# Altium schematic sheet Shunt.SchDoc
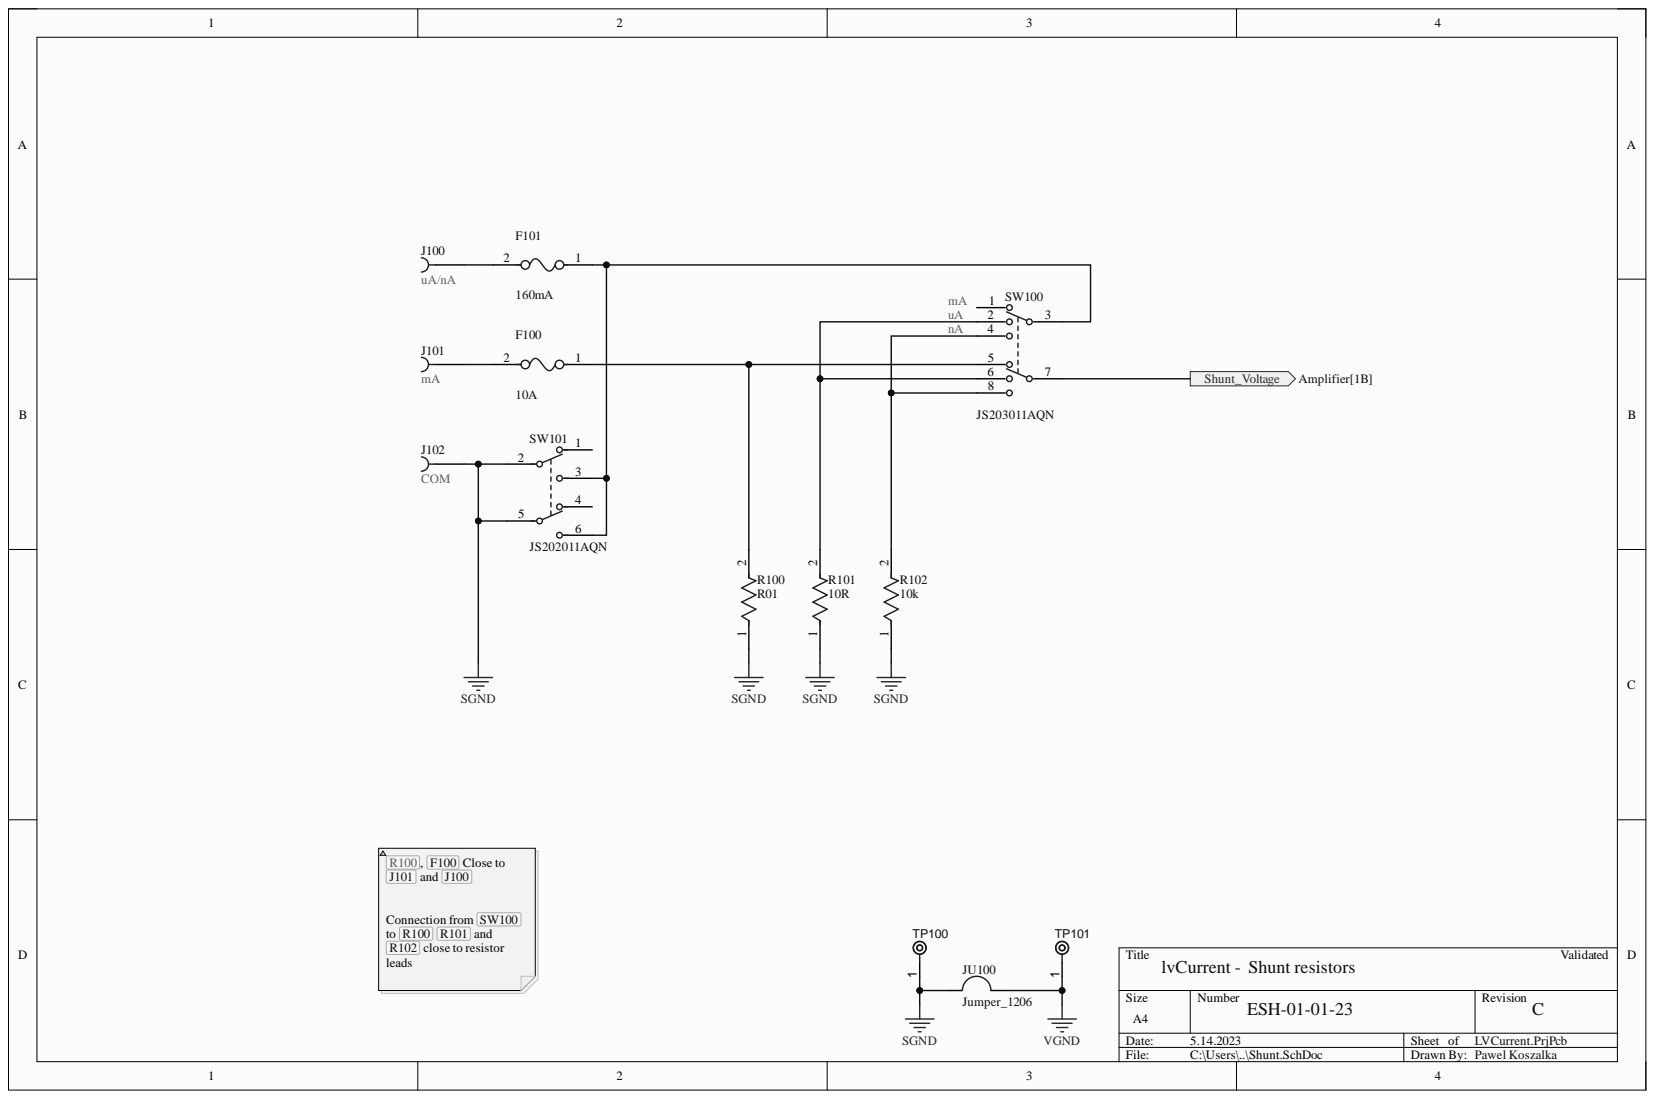
[diagram p0: Shunt.SchDoc]

## Parts
F100  10A  [FP-3413_0330_22-MFG]
F101  160mA  [FP-3413_0330_22-MFG]
J100  D-3230  [BananaConnector_4mm_long]  (value not drawn)
J101  D-3230  [BananaConnector_4mm_long]  (value not drawn)
J102  D-3231  [BananaConnector_4mm_long]  (value not drawn)
JU100  Jumper_1206  [Jumper_1206]
R100  R01  [FP-CRCW2512-e3-MFG]
R101  10R  [FP-RC1206-MFG]
R102  10k  [FP-RC1206-MFG]
SW100  JS203011AQN  [FP-JS203011AQN-MFG]
SW101  JS202011AQN  [FP-JS202011AQN-MFG]
TP100  TPAD6R  [TP06R]  (value not drawn)  (no BOM)
TP101  TPAD6R  [TP06R]  (value not drawn)  (no BOM)

## Ports
Shunt_Voltage

## Nets
SGND: J102.1 JU100.2 R100.1 R101.1 R102.1 SW101.2 SW101.5 TP100.1
VGND: JU100.1 TP101.1
Shunt_Voltage: SW100.7 ; port Shunt_Voltage
NetF100_1: F100.1 R100.2 SW100.5
NetF100_2: F100.2 J101.1
NetF101_1: F101.1 SW100.3 SW101.3 SW101.6
NetF101_2: F101.2 J100.1
NetR101_2: R101.2 SW100.2 SW100.6
NetR102_2: R102.2 SW100.4 SW100.8

Not connected: SW100 1; SW101 1 4

## Library data of the parts
F100 = CMP-26293-000001-1: 0501010.WR  1206; Manufacturer: Schurter Inc; Manufacturer Part Number: 3413.0330.22; Fuse Blow Speed: Slow; Fuse Current: 15A; Fuse I2T: 65A2Sec; Fuse Interrupt Dc Current: 100A; Fuse Voltage Drop: 57mV; Max Fuse Ac Voltage: 32V; Max Fuse Dc Voltage: 63V; Resistance: 3.5mΩ; Value: 3.5mΩ; Ambient Temperature Range High: +90°C; Ambient Temperature Range Low: -55°C; Automotive: No; Category: Fuse; Component Kind: Standard; ComponentLink2Description: Datasheet; ComponentLink2URL: https://us.schurter.com/en/datasheet/typ_UST_1206.pdf; Device Class L1: Circuit Protection; Device Class L2: Fuses; Device Class L3: unset; Digikey Description: FUSE BOARD MNT 15A 32VAC 63VDC; Height: 0.6mm; Ipc Land Pattern Name: CAPC320160X60; Lead Free: Yes; Library Name: Altium Content Vault; Mouser Description: Surface Mount Fuses UST 1206 FUSE 15A F; Octopart: https://octopart.com/3413.0330.22-schurter-8400836; Package: 0; RoHS: TRUE; Voltage Rating: 63V
F101 = CMP-26293-000001-1: 3413.0008.11  1206 Ultra fast fuse; Manufacturer: Schurter Inc; Manufacturer Part Number: 3413.0330.22; Fuse Blow Speed: Slow; Fuse Current: 15A; Fuse I2T: 65A2Sec; Fuse Interrupt Dc Current: 100A; Fuse Voltage Drop: 57mV; Max Fuse Ac Voltage: 32V; Max Fuse Dc Voltage: 63V; Resistance: 3.5mΩ; Value: 3.5mΩ; Ambient Temperature Range High: +90°C; Ambient Temperature Range Low: -55°C; Automotive: No; Category: Fuse; Component Kind: Standard; ComponentLink2Description: Datasheet; ComponentLink2URL: https://us.schurter.com/en/datasheet/typ_UST_1206.pdf; Device Class L1: Circuit Protection; Device Class L2: Fuses; Device Class L3: unset; Digikey Description: FUSE BOARD MNT 15A 32VAC 63VDC; Height: 0.6mm; Ipc Land Pattern Name: CAPC320160X60; Lead Free: Yes; Library Name: Altium Content Vault; Mouser Description: Surface Mount Fuses UST 1206 FUSE 15A F; Octopart: https://octopart.com/3413.0330.22-schurter-8400836; Package: 0; RoHS: TRUE; Voltage Rating: 63V
J100-J102 = BananaConnector_4_Long: Component Kind: Standard; Library Name: Connectors_diy.SchLib
R100 = CMP-02407-004199-1: WW25RR010FTL  1206 Resistor; Max Power Dissipation: 1W; Resistance: 100kR; Temperature Coefficient: 100ppm/°C; Tolerance: 1%; Voltage Rating: 500V; Case Code (Imperial): 2512; Case Code (Metric): 6432; Case/Package: 2512; Component Kind: Standard; Composition: Thick Film; Contact Plating: Tin; Depth: 3.15mm; Features: Automotive AEC-Q200; Height: 0.6mm; Lead Free: Lead Free; Length: 6.3mm; Library Name: Altium Content Vault; Max Operating Temperature: 155°C; Military Standard: Not; Min Operating Temperature: -55°C; Number of Pins: 2; Number of Terminations: 2; Package Quantity: 2000; Packaging: Tape and Reel; Power Rating: 1W; Radiation Hardening: No; Termination: SMD/SMT; Voltage Rating (DC): 500V; Weight: 0.001429oz; Width: 3.15mm
R101 = CMP-2100-03681-2: AR1206-10R-0.1%  1206 Resistor; Manufacturer: YAGEO; Manufacturer Part Number: RC1206JR-070RL; Power Rating: 0.25W; Resistance: 0Ω; Temperature Coefficient: 300ppm/°C; Tolerance: 5%; Value: 0Ω; Voltage Rating: 200V; Automotive: No; Category: Res; Component Kind: Standard; ComponentLink2Description: Datasheet; ComponentLink2URL: https://www.yageo.com/upload/media/product/productsearch/datasheet/rchip/PYu-RC_Group_51_RoHS_L_10.pdf; Composition: Thick Film; Device Class L1: Passive Components; Device Class L2: Resistors; Device Class L3: Chip SMD Resistors; Digikey Description: RES SMD 0 OHM JUMPER 1/4W 1206; Footprint Url: https://www.yageo.com/upload/website/yageo_PYu-R_Mount_10_19050818_343.pdf; Height: 0.65mm; Ipc Land Pattern Name: RESC310160X55; Lead Free: Yes; Library Name: Altium Content Vault; Mouser Description: Resistor: thick film; SMD; 1206; 0O; 0.25W; ±5%; -55÷155°C; Octopart: https://octopart.com/rc1206jr-070rl-yageo-40025601; Package: RC1206; RoHS: TRUE; Series: RC; Temperature: -55°C to +155°C; Temperature Range High: +155°C; Temperature Range Low: -55°C
R102 = CMP-2100-03681-2: AR1206-10K-0.1%  1206 precission reistor; Manufacturer: YAGEO; Manufacturer Part Number: RC1206JR-070RL; Power Rating: 0.25W; Resistance: 0Ω; Temperature Coefficient: 300ppm/°C; Tolerance: 5%; Value: 0Ω; Voltage Rating: 200V; Automotive: No; Category: Res; Component Kind: Standard; ComponentLink2Description: Datasheet; ComponentLink2URL: https://www.yageo.com/upload/media/product/productsearch/datasheet/rchip/PYu-RC_Group_51_RoHS_L_10.pdf; Composition: Thick Film; Device Class L1: Passive Components; Device Class L2: Resistors; Device Class L3: Chip SMD Resistors; Digikey Description: RES SMD 0 OHM JUMPER 1/4W 1206; Footprint Url: https://www.yageo.com/upload/website/yageo_PYu-R_Mount_10_19050818_343.pdf; Height: 0.65mm; Ipc Land Pattern Name: RESC310160X55; Lead Free: Yes; Library Name: Altium Content Vault; Mouser Description: Resistor: thick film; SMD; 1206; 0O; 0.25W; ±5%; -55÷155°C; Octopart: https://octopart.com/rc1206jr-070rl-yageo-40025601; Package: RC1206; RoHS: TRUE; Series: RC; Temperature: -55°C to +155°C; Temperature Range High: +155°C; Temperature Range Low: -55°C
SW100 = CMP-10038-000017-1: SWITCH SLIDE DP3T 300MA 6V; Actuator Color: Black; Actuator Material: Nylon; Actuator Type: Standard; Contact Resistance: 70mR; Electrical Life: 15000Cycles; Switch Function: On-On-On; Component Kind: Standard; Contact Current Rating: 300mA; Contact Material: Copper Alloy; Contact Plating: Silver; Depth: 5.6mm; Flammability Rating: UL94 V-2; Housing Material: Nylon; Length: 13mm; Library Name: Altium Content Vault; Max Current Rating: 300mA; Max Operating Temperature: 85°C; Max Voltage Rating (DC): 6V; Min Operating Temperature: -20°C; Mount: Through Hole; Orientation: Right Angle; Package Quantity: 1; Packaging: Tray; Radiation Hardening: No; Series: JS; Termination: PC Pins; Throw Configuration: DP3T
SW101 = CMP-10038-000021-1: SWITCH SLIDE DPDT 300MA 6V; Actuator Color: Black; Actuator Length: 2mm; Actuator Material: Nylon; Actuator Type: Standard; Contact Resistance: 70mR; Electrical Life: 5000Cycles; Illumination Type: Non-Illuminated; Switch Function: On-On; Component Kind: Standard; Contact Current Rating: 300mA; Contact Material: Copper Alloy; Contact Plating: Silver; Current Rating: 300mA; Depth: 5.6mm; Housing Material: Nylon; Length: 9mm; Library Name: Altium Content Vault; Max Current Rating: 300mA; Max Operating Temperature: 85°C; Max Voltage Rating (DC): 6V; Min Operating Temperature: -40°C; Mount: Through Hole; Orientation: Right Angle; Package Quantity: 1; Packaging: Bulk; Radiation Hardening: No; REACH SVHC: No SVHC; RoHS Compliant: Yes; Series: JS; Switch Travel: 2mm; Termination: PC Pins; Throw Configuration: DPDT; Voltage Rating (DC): 6V
TP100 TP101 = CMP-00028-00002-2: 0.6mm round; Library Name: LabDevices; Pins: 1
JU100 = Jumper_1206: Component Kind: Standard; Library Name: Jumpers.SchLib
